# Altium schematic sheet Sheet1.SchDoc
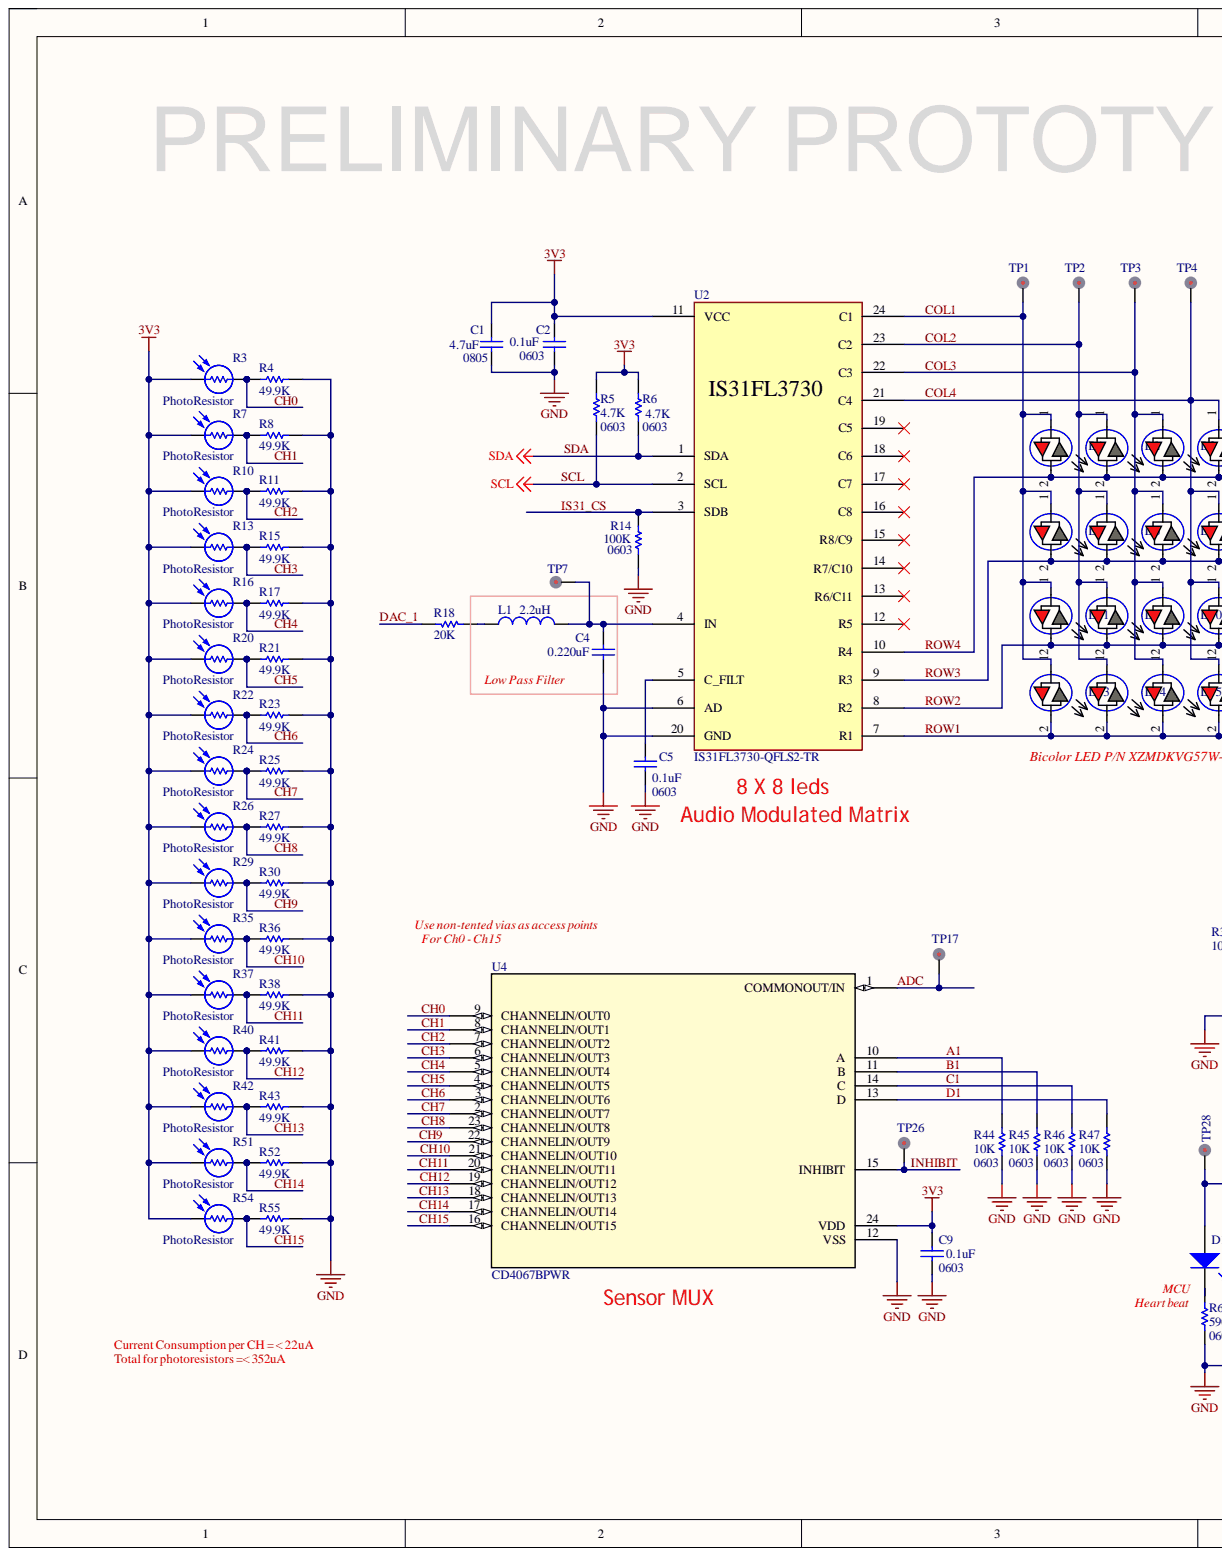
[diagram p0: Sheet1.SchDoc, left]
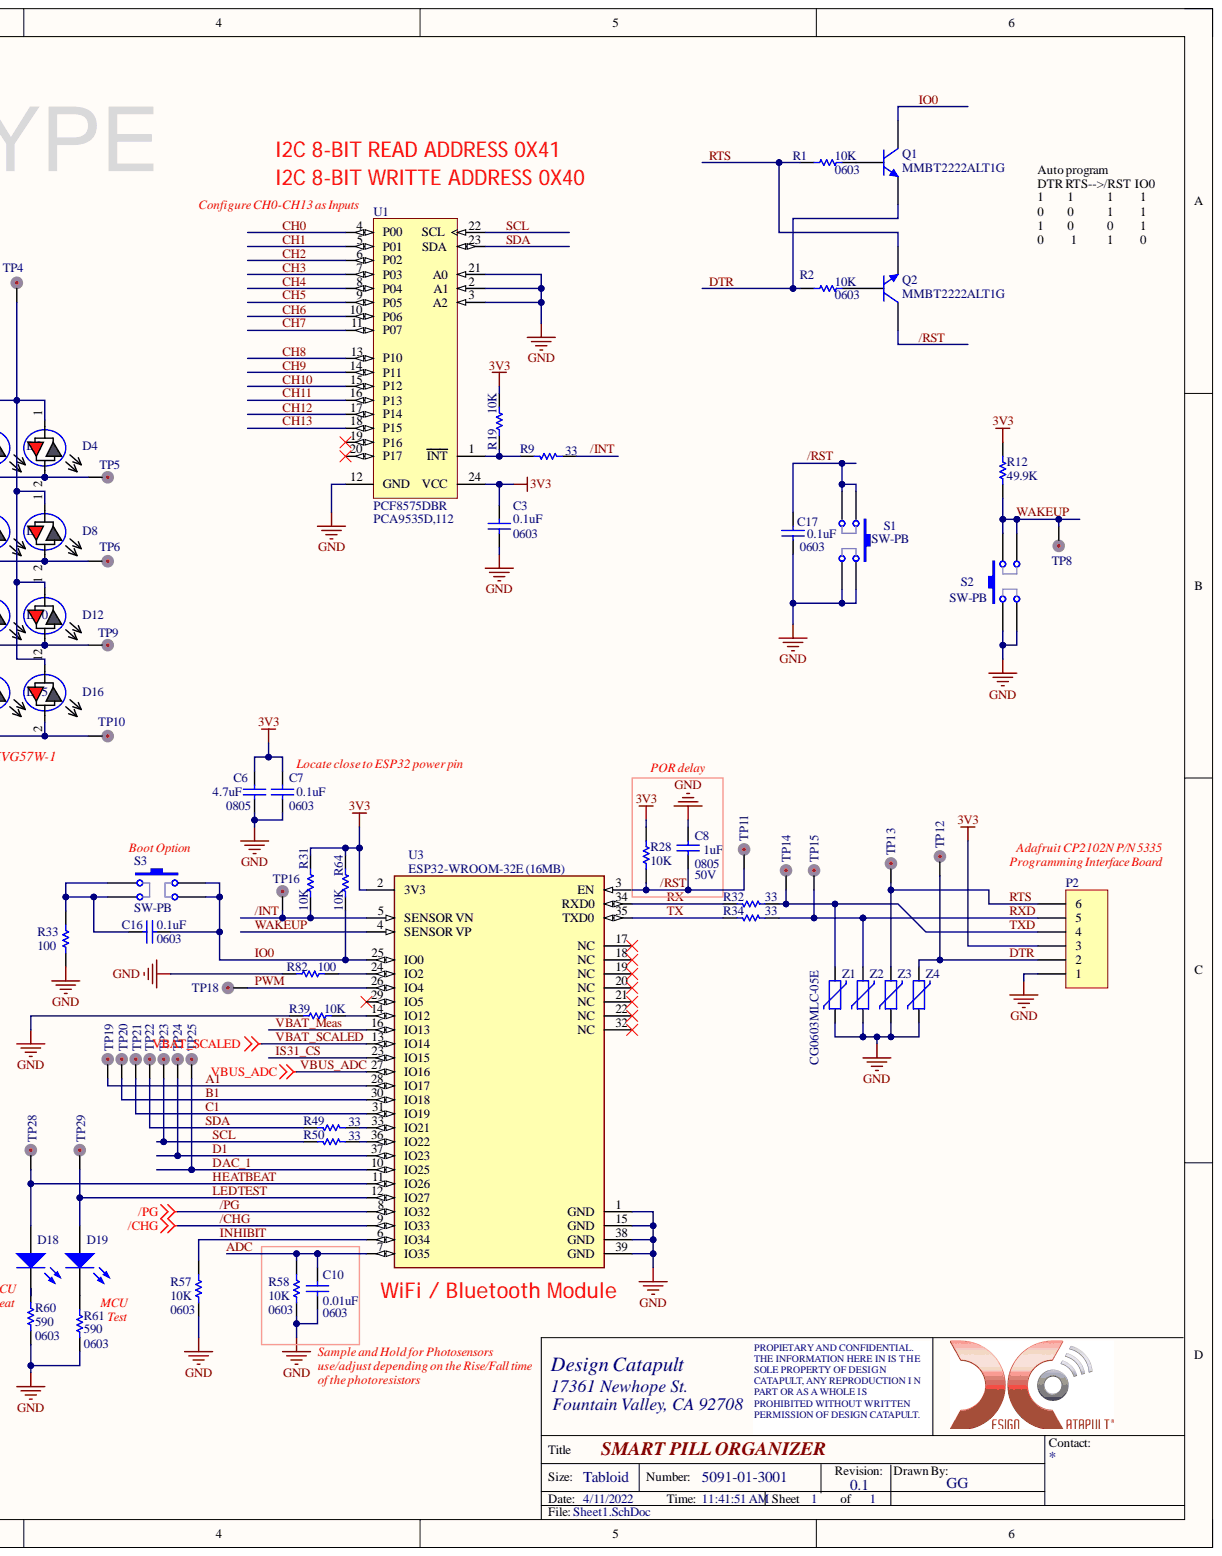
[diagram p1: Sheet1.SchDoc, right]

## Parts
C1  value 4.7uF  Package: 0805  [CAPC2012M]
C2  0.1uF  Package: 0603  [CAPC1608X90N]
C3  0.1uF  Package: 0603  [CAPC1608X90N]
C4  0.220uF  [CAPC1608X90N]
C5  0.1uF  Package: 0603  [CAPC1608X90N]
C6  value 4.7uF  Package: 0805  [CAPC2012M]
C7  0.1uF  Package: 0603  [CAPC1608X90N]
C8  1uF  Package: 0805  Voltage: 50V  [CAPC2012M]
C9  0.1uF  Package: 0603  [CAPC1608X90N]
C10  0.01uF  Package: 0603  [CAPC1608X90N]
C16  0.1uF  Package: 0603  [CAPC1608X90N]
C17  0.1uF  Package: 0603  [CAPC1608X90N]
D1  Led_Red_Green  [LED-RG-SMD]  (value not drawn)
D2  Led_Red_Green  [LED-RG-SMD]  (value not drawn)
D3  Led_Red_Green  [LED-RG-SMD]  (value not drawn)
D4  Led_Red_Green  [LED-RG-SMD]  (value not drawn)
D5  Led_Red_Green  [LED-RG-SMD]  (value not drawn)
D6  Led_Red_Green  [LED-RG-SMD]  (value not drawn)
D7  Led_Red_Green  [LED-RG-SMD]  (value not drawn)
D8  Led_Red_Green  [LED-RG-SMD]  (value not drawn)
D9  Led_Red_Green  [LED-RG-SMD]  (value not drawn)
D10  Led_Red_Green  [LED-RG-SMD]  (value not drawn)
D11  Led_Red_Green  [LED-RG-SMD]  (value not drawn)
D12  Led_Red_Green  [LED-RG-SMD]  (value not drawn)
D13  Led_Red_Green  [LED-RG-SMD]  (value not drawn)
D14  Led_Red_Green  [LED-RG-SMD]  (value not drawn)
D15  Led_Red_Green  [LED-RG-SMD]  (value not drawn)
D16  Led_Red_Green  [LED-RG-SMD]  (value not drawn)
D18  LED0603  [LED-0603-GREEN]  (value not drawn)
D19  LED0603  [LED-0603-GREEN]  (value not drawn)
L1  2.2uH  [INDC2012M]
P2  (no value)  [HDR1X6]
Q1  MMBT2222ALT1G  [SOT23-3N]
Q2  MMBT2222ALT1G  [SOT23-3N]
R1  10K  Package: 0603  [J1-0603]
R2  10K  Package: 0603  [J1-0603]
R3  PhotoResistor  [PHOTORESISTOR]
R4  49.9K  [0603R]
R5  4.7K  Package: 0603  [0603R]
R6  4.7K  Package: 0603  [0603R]
R7  PhotoResistor  [PHOTORESISTOR]
R8  49.9K  [0603R]
R9  33  [0603R]
R10  PhotoResistor  [PHOTORESISTOR]
R11  49.9K  [0603R]
R12  49.9K  [0603R]
R13  PhotoResistor  [PHOTORESISTOR]
R14  100K  Package: 0603  [0603R]
R15  49.9K  [0603R]
R16  PhotoResistor  [PHOTORESISTOR]
R17  49.9K  [0603R]
R18  20K  [0603R]
R19  10K  [0603R]
R20  PhotoResistor  [PHOTORESISTOR]
R21  49.9K  [0603R]
R22  PhotoResistor  [PHOTORESISTOR]
R23  49.9K  [0603R]
R24  PhotoResistor  [PHOTORESISTOR]
R25  49.9K  [0603R]
R26  PhotoResistor  [PHOTORESISTOR]
R27  49.9K  [0603R]
R28  10K  [0603R]
R29  PhotoResistor  [PHOTORESISTOR]
R30  49.9K  [0603R]
R31  10K  [0603R]
R32  33  [0603R]
R33  100  [0603R]
R34  33  [0603R]
R35  PhotoResistor  [PHOTORESISTOR]
R36  49.9K  [0603R]
R37  PhotoResistor  [PHOTORESISTOR]
R38  49.9K  [0603R]
R39  10K  [0603R]
R40  PhotoResistor  [PHOTORESISTOR]
R41  49.9K  [0603R]
R42  PhotoResistor  [PHOTORESISTOR]
R43  49.9K  [0603R]
R44  10K  Package: 0603  [0603R]
R45  10K  Package: 0603  [0603R]
R46  10K  Package: 0603  [0603R]
R47  10K  Package: 0603  [0603R]
R49  33  [0603R]
R50  33  [0603R]
R51  PhotoResistor  [PHOTORESISTOR]
R52  49.9K  [0603R]
R54  PhotoResistor  [PHOTORESISTOR]
R55  49.9K  [0603R]
R57  10K  Package: 0603  [0603R]
R58  10K  Package: 0603  [0603R]
R60  590  Package: 0603  [0603R]
R61  590  Package: 0603  [0603R]
R64  10K  [0603R]
R82  100  [0603R]
S1  SW-PB  [435151014824]
S2  SW-PB  [435151014824]
S3  SW-PB  [435151014824]
TP1  Pogo Pin  [TP-TH-PogoPin]  (value not drawn)
TP2  Pogo Pin  [TP-TH-PogoPin]  (value not drawn)
TP3  Pogo Pin  [TP-TH-PogoPin]  (value not drawn)
TP4  Pogo Pin  [TP-TH-PogoPin]  (value not drawn)
TP5  Pogo Pin  [TP-TH-PogoPin]  (value not drawn)
TP6  Pogo Pin  [TP-TH-PogoPin]  (value not drawn)
TP7  Pogo Pin  [TP-TH-PogoPin]  (value not drawn)
TP8  Pogo Pin  [TP-TH-PogoPin]  (value not drawn)
TP9  Pogo Pin  [TP-TH-PogoPin]  (value not drawn)
TP10  Pogo Pin  [TP-TH-PogoPin]  (value not drawn)
TP11  Pogo Pin  [TP-TH-PogoPin]  (value not drawn)
TP12  Pogo Pin  [TP-TH-PogoPin]  (value not drawn)
TP13  Pogo Pin  [TP-TH-PogoPin]  (value not drawn)
TP14  Pogo Pin  [TP-TH-PogoPin]  (value not drawn)
TP15  Pogo Pin  [TP-TH-PogoPin]  (value not drawn)
TP16  Pogo Pin  [TP-TH-PogoPin]  (value not drawn)
TP17  Pogo Pin  [TP-TH-PogoPin]  (value not drawn)
TP18  Pogo Pin  [TP-TH-PogoPin]  (value not drawn)
TP19  Pogo Pin  [TP-TH-PogoPin]  (value not drawn)
TP20  Pogo Pin  [TP-TH-PogoPin]  (value not drawn)
TP21  Pogo Pin  [TP-TH-PogoPin]  (value not drawn)
TP22  Pogo Pin  [TP-TH-PogoPin]  (value not drawn)
TP23  Pogo Pin  [TP-TH-PogoPin]  (value not drawn)
TP24  Pogo Pin  [TP-TH-PogoPin]  (value not drawn)
TP25  Pogo Pin  [TP-TH-PogoPin]  (value not drawn)
TP26  Pogo Pin  [TP-TH-PogoPin]  (value not drawn)
TP28  Pogo Pin  [TP-TH-PogoPin]  (value not drawn)
TP29  Pogo Pin  [TP-TH-PogoPin]  (value not drawn)
U1  PCF8575DBR  [SOIC24_L]
U2  IS31FL3730-QFLS2-TR  [QFN24 - 4X4MM - PITCH 0.5MM - EXPOSEDPAD]
U3  ESP32-WROOM-32E (16MB)  [ESP32-WROOM-32E_16MB-MFG]
U4  CD4067BPWR  [24TSSOP]
Z1  CG0603MLC-05E  [0603F]
Z2  CG0603MLC-05E  [0603F]
Z3  CG0603MLC-05E  [0603F]
Z4  CG0603MLC-05E  [0603F]

## Nets
3V3: C1.2 C2.2 C3.2 C6.2 C7.2 C9.2 P2.3 R3.1 R5.1 R6.1 R7.1 R10.1 R12.2 R13.1 R16.1 R19.2 R20.1 R22.1 R24.1 R26.1 R28.1 R29.1 R31.2 R35.1 R37.1 R40.1 R42.1 R51.1 R54.1 R64.2 U1.24(VCC) U2.11(VCC) U3.2(3V3) U4.24(VDD)
GND: C1.1 C2.1 C3.1 C4.1 C5.1 C6.1 C7.1 C8.2 C9.1 C10.1 C17.2 P2.1 R4.2 R8.2 R11.2 R14.2 R15.2 R17.2 R21.2 R23.2 R25.2 R27.2 R30.2 R33.2 R36.2 R38.2 R39.2 R41.2 R43.2 R44.2 R45.2 R46.2 R47.2 R52.2 R55.2 R57.2 R58.2 R60.1 R61.1 R82.1 S1.3 S1.4 S2.1 S2.2 U1.2(A1) U1.3(A2) U1.12(GND) U1.21(A0) U2.6(AD) U2.20(GND) U3.1(GND) U3.15(GND) U3.38(GND) U3.39(GND) U4.12(VSS) Z1.1 Z2.1 Z3.1 Z4.1
/CHG: U3.9(IO33)
/INT: R9.2 R31.1 TP16.1 U3.5(SENSOR_VN)
/PG: U3.8(IO32)
/RST: C8.1 C17.1 Q2.1 R28.2 S1.1 S1.2 TP11.1 U3.3(EN)
A1: R44.1 TP19.1 U3.28(IO17) U4.10(A)
ADC: C10.2 R58.1 TP17.1 U3.7(IO35) U4.1(COMMONOUT/IN)
B1: R45.1 TP20.1 U3.30(IO18) U4.11(B)
C1: R46.1 TP21.1 U3.31(IO19) U4.14(C)
CH0: R3.2 R4.1 U1.4(P00) U4.9(CHANNELIN/OUT0)
CH1: R7.2 R8.1 U1.5(P01) U4.8(CHANNELIN/OUT1)
CH2: R10.2 R11.1 U1.6(P02) U4.7(CHANNELIN/OUT2)
CH3: R13.2 R15.1 U1.7(P03) U4.6(CHANNELIN/OUT3)
CH4: R16.2 R17.1 U1.8(P04) U4.5(CHANNELIN/OUT4)
CH5: R20.2 R21.1 U1.9(P05) U4.4(CHANNELIN/OUT5)
CH6: R22.2 R23.1 U1.10(P06) U4.3(CHANNELIN/OUT6)
CH7: R24.2 R25.1 U1.11(P07) U4.2(CHANNELIN/OUT7)
CH8: R26.2 R27.1 U1.13(P10) U4.23(CHANNELIN/OUT8)
CH9: R29.2 R30.1 U1.14(P11) U4.22(CHANNELIN/OUT9)
CH10: R35.2 R36.1 U1.15(P12) U4.21(CHANNELIN/OUT10)
CH11: R37.2 R38.1 U1.16(P13) U4.20(CHANNELIN/OUT11)
CH12: R40.2 R41.1 U1.17(P14) U4.19(CHANNELIN/OUT12)
CH13: R42.2 R43.1 U1.18(P15) U4.18(CHANNELIN/OUT13)
CH14: R51.2 R52.1 U4.17(CHANNELIN/OUT14)
CH15: R54.2 R55.1 U4.16(CHANNELIN/OUT15)
COL1: D1.1 D5.1 D11.1 D13.1 TP1.1 U2.24(C1)
COL2: D2.1 D6.1 D9.1 D14.1 TP2.1 U2.23(C2)
COL3: D3.1 D7.1 D10.1 D15.1 TP3.1 U2.22(C3)
COL4: D4.1 D8.1 D12.1 D16.1 TP4.1 U2.21(C4)
D1: R47.1 TP24.1 U3.37(IO23) U4.13(D)
DAC_1: R18.1 TP25.1 U3.10(IO25)
DTR: P2.2 Q1.2 R2.2 TP12.1 Z4.2
HEATBEAT: D18.1 TP28.1 U3.11(IO26)
INHIBIT: R57.1 TP26.1 U3.6(IO34) U4.15(INHIBIT)
IO0: C16.1 Q1.1 R64.1 S3.3 S3.4 U3.25(IO0)
IS31_CS: R14.1 U2.3(SDB) U3.23(IO15)
LEDTEST: D19.1 TP29.1 U3.12(IO27)
PWM: TP18.1 U3.26(IO4)
ROW1: D13.2 D14.2 D15.2 D16.2 TP10.1 U2.7(R1)
ROW2: D9.2 D10.2 D11.2 D12.2 TP9.1 U2.8(R2)
ROW3: D5.2 D6.2 D7.2 D8.2 TP6.1 U2.9(R3)
ROW4: D1.2 D2.2 D3.2 D4.2 TP5.1 U2.10(R4)
RTS: P2.6 Q2.2 R1.2 TP13.1 Z3.2
RX: R32.1 U3.34(RXD0)
RXD: P2.5 R34.2 TP15.1 Z2.2
SCL: R5.2 R50.1 TP23.1 U1.22(SCL) U2.2(SCL)
SDA: R6.2 R49.1 TP22.1 U1.23(SDA) U2.1(SDA)
TX: R34.1 U3.35(TXD0)
TXD: P2.4 R32.2 TP14.1 Z1.2
VBAT_Meas: U3.16(IO13)
VBAT_SCALED: U3.13(IO14)
VBUS_ADC: U3.27(IO16)
WAKEUP: R12.1 S2.3 S2.4 TP8.1 U3.4(SENSOR_VP)
NetC4_2: C4.2 L1.2 TP7.1 U2.4(IN)
NetC5_2: C5.2 U2.5(C_FILT)
NetC16_2: C16.2 R33.1 S3.1 S3.2
NetD18_2: D18.2 R60.2
NetD19_2: D19.2 R61.2
NetL1_1: L1.1 R18.2
NetQ1_3: Q1.3 R1.1
NetQ2_3: Q2.3 R2.1
NetR9_1: R9.1 R19.1 U1.1(INT)
NetR39_1: R39.1 U3.14(IO12)
NetR49_2: R49.2 U3.33(IO21)
NetR50_2: R50.2 U3.36(IO22)
NetR82_2: R82.2 U3.24(IO2)

Not connected: U1 19(P16) 20(P17); U2 12(R5) 13(R6/C11) 14(R7/C10) 15(R8/C9) 16(C8) 17(C7) 18(C6) 19(C5); U3 17(NC) 18(NC) 19(NC) 20(NC) 21(NC) 22(NC) 29(IO5) 32(NC)

## Library data of the parts
C1 C6 = CMP-2007-04610-1: CAP CER 4.7UF 50V X7R 0805; Manufacturer: TDK Corporation; Manufacturer Part Number: CGA4J1X7R1H475K125AC; Component Kind: Standard; Library Name: 3461-03-3104.PcbLib; Part Number: CGA4J1X7R1H475K125AC; Voltage: 50V
C2 C3 C5 C7 C9 C16 C17 = Cap: CAP CER 0.1UF 50V X7R 0603; Component Kind: Standard; Library Name: Miscellaneous Devices.IntLib; Value: 0.1uF
C4 = Cap: CAP CER 0.220uF 50V X7R 0603; Component Kind: Standard; Library Name: Miscellaneous Devices.IntLib; Package: 0603; Value: 0.220uF
C8 = Cap: CAP CER 1UF 50V X5R 0805; Manufacturer: TDK Corporation; Manufacturer Part Number: C2012X5R1H105K125AB; Component Kind: Standard; Library Name: Miscellaneous Devices.IntLib; Value: 1uF
C10 = Cap: Capacitor; Component Kind: Standard; Library Name: Miscellaneous Devices.IntLib; Value: 0.01uF
D18 D19 = LED0603: LED GREEN CLEAR CHIP SMD 0603; Component Kind: Standard; LatestRevisionDate: 08-Jul-2005; LatestRevisionNote: Mech Layer 1 removed.; PackageDescription: LED; 2 Leads; PackageReference: LED-0; Published: 8-Jun-2000; Simulation: LED0; Library Name: DesignCatapultLib.SchLib; Publisher: Altium Limited
L1 = Inductor: FIXED IND 2.2UH 600MA 425 MOHM 0805; Manufacturer: Murata Electronics; Manufacturer Part Number: LQM21PN2R2MC0D; Code_IEC: 1005; Code_JEITA: 0402; Component Kind: Standard; LatestRevisionDate: 29-May-2009; LatestRevisionNote: IPC-7351 Footprint Added.; Library Name: Miscellaneous Devices.IntLib; Manufacturer Part Number2: 74479775222; PackageDescription: Chip Inductor; PackageReference: 0402-A; Published: 8-Jun-2000; Signal Integrity: Inductor; Simulation: INDUCTOR; Value: 2.2uH; Publisher: Altium Limited
P2 = Header 6: Header, 6-Pin; Component Kind: Standard; LatestRevisionDate: 17-Jul-2002; LatestRevisionNote: Re-released for DXP Platform.; Library Name: Miscellaneous Connectors.IntLib; Signal Integrity: Connector; Publisher: Altium Limited
Q1 Q2 = 2N3904: NPN General Purpose Amplifier; Component Kind: Standard; Library Name: Miscellaneous Devices.IntLib; Manufacturer Part Number: MMBT2222ALT1G
R1 R2 = Res1: Resistor; Component Kind: Standard; Library Name: Miscellaneous Devices.IntLib; Value: 10K
R3 R7 R10 R13 R16 R20 R22 R24 R26 R29 R35 R37 R40 R42 R51 R54 = PhotoResistor: Rectangular Thick Film Chip Resistor, 10 Ohm to 330k Ohm Range, 0.1% and 0.5% Tolerance, 0603 Size, 0.063 W; Note: If flow soldering, adjust pad width of PCB Footprint to 0.7 - 0.8 times the width of the resistor.; Code_IPC: 1608 [0603]; Component Kind: Standard; ComponentLink1Description: Manufacturer Link; ComponentLink1URL: http://www.panasonic.com/industrial/components/resistive_products.htm; ComponentLink2Description: Datasheet; ComponentLink2URL: http://www.panasonic.com/industrial/components/pdf/AOA0000CE8.pdf; LatestRevisionDate: 19-Mar-2004; LatestRevisionNote: Silkscreen size reduced.; PackageDocument: Mar-2003; PackageReference: ERA-0603; Published: 17-Dec-2003; Library Name: MannySchlib1.SchLib; Publisher: Altium Limited
R4 R8 R11 R12 R15 R17 R21 R23 R25 R27 R30 R36 R38 R41 R43 R52 R55 = ERA3Y: RES SMD 49.9K OHM 1% 1/10W 0603; Note: If flow soldering, adjust pad width of PCB Footprint to 0.7 - 0.8 times the width of the resistor.; Code_IPC: 1608 [0603]; Component Kind: Standard; ComponentLink1Description: Manufacturer Link; ComponentLink1URL: http://www.panasonic.com/industrial/components/resistive_products.htm; ComponentLink2Description: Datasheet; ComponentLink2URL: http://www.panasonic.com/industrial/components/pdf/AOA0000CE8.pdf; LatestRevisionDate: 19-Mar-2004; LatestRevisionNote: Silkscreen size reduced.; PackageDocument: Mar-2003; PackageReference: ERA-0603; Published: 17-Dec-2003; Signal Integrity: Res; Simulation: RESISTOR; Value: 49.9K; Library Name: MannySchlib1.SchLib; Publisher: Altium Limited
R5 R6 = Res1: RES SMD 4.7K OHM 1% 1/10W 0603; Manufacturer: VISHAY Beyschlag; Component Kind: Standard; Library Name: Miscellaneous Devices.IntLib; Value: 4.7K
R9 R32 R34 R49 R50 = Res1: RES SMD 33 OHM 1% 1/10W 0603; DSPM Part Number: 003-0404-00; Component Kind: Standard; Library Name: Miscellaneous Devices.IntLib; Published: 8-Jun-2000; Revision: July-2002: Re-released for DXP Platform.; Signal Integrity: Res; Simulation: RESISTOR; Value: 33; Publisher: Altium Limited
R14 = Res1: RES SMD 100K OHM 1% 1/10W 0603; Manufacturer: VISHAY Beyschlag; Component Kind: Standard; Library Name: Miscellaneous Devices.IntLib; Value: 100K
R18 = Res1: RES SMD 20K OHM 1% 1/10W 0603; Component Kind: Standard; Published: 8-Jun-2000; Revision: July-2002: Re-released for DXP Platform.; Tolerance: 1%; Value: 20K; Publisher: Altium Limited
R19 R28 R31 R39 R44-R47 R57 R58 R64 = Res1: RES SMD 10K OHM 1% 1/10W 0603; Manufacturer: VISHAY Beyschlag; Component Kind: Standard; Library Name: Miscellaneous Devices.IntLib; Value: 10K
  R19 R28 R31 R39 R64: Package: 0603
R33 R82 = Res1: RES SMD 100 OHM 1% 1/10W 0603; Manufacturer: VISHAY Beyschlag; Component Kind: Standard; Library Name: Miscellaneous Devices.IntLib; Package: 0603; Value: 100
R60 R61 = Res1: RES 590 OHM 1% 1/10W 0603; Manufacturer: Yageo; Manufacturer Part Number: RC0603FR-07590RL; Component Kind: Standard; DESC: RES 590 OHM 1% 1/10W 0603; Library Name: Miscellaneous Devices.IntLib; Value: 590
S1-S3 = SW-PB: SWITCH TACTILE SPST-NO 0.05A 12V HEIGHT 12.5mm; Manufacturer: Wurth; Component Kind: Standard; LatestRevisionDate: 17-Jul-2002; LatestRevisionNote: Re-released for DXP Platform.; Manufacturer Part Number: 435151014824; PackageDescription: Switch; 2 Leads; PackageReference: SPST-2; Published: 24-Mar-1999; Library Name: DesignCatapultLib.SchLib; Publisher: Altium Limited
TP1-TP26 TP28 TP29 = TEST POINT POGO: Test Point 150mil Hole 65mil; Manufacturer Part Number: P13-4023; Component Kind: Standard; Part Number2: P13-0123; Part Number3: P13-1123; Part Number4: P13-2123; Library Name: DesignCatapultLib.SchLib
U1 = CMP-0314-00227-2: Remote 16-Bit I2C and SMBus I/O Expander with Interrupt Output, 2.5 to 5.5 V, -40 to 85 degC, 24-pin SSOP (DB), Green (RoHS & no Sb/Br); Manufacturer: Texas Instruments; # of I/Os: 16; fSCLK(Max)(MHz): 0.4; I2C Address: 0100 xxx; Interrupt Output: Yes; Max Frequency(kHz): 400; SubFamily: I2C IO Expander; VCC(Max)(V): 5.5; VCC(Min)(V): 2.5; Voltage Nodes(V): 5.5, 3.6, 2.7, 2.5; Code_JEDEC: MO-150; Component Kind: Standard; ComponentLink1Description: Datasheet; ComponentLink1URL: http://www.ti.com/general/docs/lit/getliterature.tsp?genericPartNumber=PCF8575&fileType=pdf; ComponentLink2Description: Package Specification; ComponentLink2URL: http://www.ti.com/litv/pdf/mpds211a; DatasheetVersion: SCPS121D; Eco-Plan: Green (RoHS & no Sb/Br); Library Name: Altium Content Vault; Mounting Technology: Surface Mount; PackageDescription: 24-Pin Plastic Small Outline Package, 8.2 x 5.3 mm Body, 0.65 mm Pitch; PackageReference: DB0024A; PackageVersion: revE, Dec-2001; PartNumber: PCF8575DBR; Push-Pull I/O Type: Yes; Reset Input: No; Vcc range(V): 2.5 to 5.5
U3 = CMP-194065-000027-1: RX TXRX MOD WIFI TRACE ANT SMD; Component Kind: Standard; Library Name: Altium Content Vault
U4 = CD4067BPWR: No Description Available; Mfr_Name: Texas Instruments; Component Kind: Standard; Manufacturer_Part_Number: CD4067BPWR; RefDes: RefDes; Type: DEV; Copyright: Copyright (C) 2022 Ultra Librarian. All rights reserved.; Library Name: MannySchlib1.SchLib
Z1-Z4 = VARISTORCN0603: Varistor 1 Circuit Surface Mount, MLCV 0603 (1608 Metric), 12V 0.5 pF @ 1 MHz; Manufacturer: Bourns Inc.; Component Kind: Standard; Manufacturer Part Number: CG0603MLC-05E; Library Name: DesignCatapultLib.SchLib
D1-D16 = Led_Red_Green: Component Kind: Standard; Library Name: DesignCatapultLib.SchLib
U2 = IS31FL3730-QFLS2-TR: Component Kind: Standard; Library Name: MannySchlib1.SchLib
